# Altium schematic sheet BMB_Microcontroller.SchDoc(BMB_Microcontroller) (continued)

## Library data of the parts
C1 C2 C7 C9 C12-C15 C31 C35 C36 C40 = CAP: Capacitor; Manufacturer Part Number: GRM188R71E104KA01D; Manufacturer: Murata; Voltage Rating (DC): 25V; Tolerance: 10%; Material: Ceramic; Dielectric: X7R; Width: 0.8mm; Weight: 7.1E-05oz; Voltage Rating: 25V; Voltage: 25V; Thickness: 0.035inch; Termination: SMD/SMT; Supplier Part Number 1: 490-1524-1-ND; Supplier 1: Digikey; Stock 1: 6777948; REACH SVHC: Unknown; Radiation Hardening: No; Pricing 1: 1=0.1, 10=0.022, 100=0.0099, 500=0.0071, 1000=0.0056 (USD); Packaging: Tape and Reel; Package Quantity: 1; Number of Pins: 2; Mount: Surface Mount; Min Operating Temperature: -55°C; Max Operating Temperature: 125°C; Library Name: Formula_Library.SchLib; Length: 1.6mm; Lead Free: Lead Free; Height: 0.8mm; Depth: 0.8mm; ComponentLink8URL: https://datasheet.ciiva.com/29202/451555-da-01-en-vs-kondensator-0603-29202150.pdf; ComponentLink8Description: https://datasheet.ciiva.com/29202/451555-da-01-en-vs-kondensator-0603-29202150.pdf; ComponentLink7URL: https://datasheet.ciiva.com/18101/getdatasheetpartid-44549-18101373.pdf; ComponentLink7Description: https://datasheet.ciiva.com/18101/getdatasheetpartid-44549-18101373.pdf; ComponentLink6URL: https://datasheet.ciiva.com/34302/1857495-34302860.pdf; ComponentLink6Description: https://datasheet.ciiva.com/34302/1857495-34302860.pdf; ComponentLink5URL: https://datasheet.ciiva.com/22703/1747454-22703336.pdf; ComponentLink5Description: https://datasheet.ciiva.com/22703/1747454-22703336.pdf; ComponentLink4URL: https://datasheet.ciiva.com/16199/product-16199382.pdf; ComponentLink4Description: https://datasheet.ciiva.com/16199/product-16199382.pdf; ComponentLink3URL: https://datasheet.ciiva.com/129/partnumbering-e-01-129842.pdf; ComponentLink3Description: https://datasheet.ciiva.com/129/partnumbering-e-01-129842.pdf; ComponentLink2URL: https://datasheet.ciiva.com/129/partnumbering-e-01-129841.pdf; ComponentLink2Description: https://datasheet.ciiva.com/129/partnumbering-e-01-129841.pdf; ComponentLink1URL: https://datasheet.ciiva.com/129/product-129840.pdf; ComponentLink1Description: https://datasheet.ciiva.com/129/product-129840.pdf; Component Kind: Standard; Case Code (Metric): 1608; Case Code (Imperial): 0603; Case/Package: 0603
C3-C6 C8 C10 C11 = CAP: Capacitor; Manufacturer Part Number: C0603C102K5RACAUTO; Manufacturer: KEMET; Voltage Rating (DC): 50V; Tolerance: 10%; Dissipation Factor: 2.5%; Dielectric Material: Ceramic; Dielectric: X7R; Width: 0.031inch; Voltage Rating: 50V; Thickness: 0.034inch; Termination: SMD/SMT; Supplier Part Number 1: 399-6849-1-ND; Supplier 1: Digi-Key; Stock 1: 45295; REACH SVHC: No SVHC; Radiation Hardening: No; Pricing 1: 1=0.1, 10=0.076, 100=0.0341, 500=0.0243, 1000=0.0191 (USD); Packaging: Tape and Reel; Package Quantity: 1; Mount: Surface Mount; Min Operating Temperature: -55°C; Max Operating Temperature: 125°C; Library Name: Formula_Library.SchLib; Length: 1.6mm; Height: 0.8mm; Depth: 0.8mm; ComponentLink2URL: https://datasheet.ciiva.com/22693/1633156-22693960.pdf; ComponentLink2Description: https://datasheet.ciiva.com/22693/1633156-22693960.pdf; ComponentLink1URL: https://datasheet.ciiva.com/7823/1633156-7823575.pdf; ComponentLink1Description: https://datasheet.ciiva.com/7823/1633156-7823575.pdf; Component Kind: Standard; Case Code (Metric): 1608; Case Code (Imperial): 0603; Case/Package: 0603
C16 C21 = Cap: Capacitor; Manufacturer Part Number: CGA3E2X7R1H103K080AA; Manufacturer: TDK Corporation; Voltage - Rated: 50V; Tolerance: ±10%; Temperature Coefficient: X7R; Thickness (Max): 0.035" (0.90mm); Supplier Part Number 1: 478-1227-1-ND; Supplier 1: Digi-Key; Stock: 148450; Size / Dimension: 0.063" L x 0.031" W (1.60mm x 0.80mm); Simulation: CAP; Signal Integrity: Cap; RoHS: RoHS Compliant; Ratings: AEC-Q200; Pricing: 1=0.1, 10=0.036, 100=0.0165, 250=0.01352, 500=0.01156, 1000=0.009 (USD); Packaging: Cut Tape (CT); Package / Case: 0603 (1608 Metric); Operating Temperature: -55°C ~ 125°C; Mounting Type: Surface Mount, MLCC; Library Name: Miscellaneous Devices.IntLib; Lead Style: -; Lead Spacing: -; Height - Seated (Max): -; Features: -; ComponentLink1URL: https://product.tdk.com/info/en/catalog/datasheets/mlcc_automotive_general_en.pdf; ComponentLink1Description: https://product.tdk.com/info/en/catalog/datasheets/mlcc_automotive_general_en.pdf; Component Kind: Standard; Category: Capacitors; Applications: Automotive
C17-C20 C22-C26 C39 = Cap: Capacitor; Manufacturer Part Number: CGA3E1X7R1E105M080AC; Manufacturer: TDK Corporation; Voltage - Rated: 25V; Tolerance: ±20%; Temperature Coefficient: X7R; Thickness (Max): 0.035" (0.90mm); Supplier Part Number 1: 490-1536-1-ND; Supplier 1: Digi-Key; Stock: 23693; Size / Dimension: 0.063" L x 0.031" W (1.60mm x 0.80mm); Simulation: CAP; Signal Integrity: Cap; RoHS: RoHS Compliant; Ratings: AEC-Q200; Pricing: 1=0.17, 10=0.12, 100=0.057, 250=0.048, 500=0.0402, 1000=0.033 (USD); Packaging: Cut Tape (CT); Package / Case: 0603 (1608 Metric); Operating Temperature: -55°C ~ 125°C; Mounting Type: Surface Mount, MLCC; Library Name: Miscellaneous Devices.IntLib; Lead Style: -; Lead Spacing: -; Height - Seated (Max): -; Features: -; ComponentLink1URL: https://product.tdk.com/info/en/catalog/datasheets/mlcc_automotive_general_en.pdf; ComponentLink1Description: https://product.tdk.com/info/en/catalog/datasheets/mlcc_automotive_general_en.pdf; Component Kind: Standard; Category: Capacitors; Applications: Automotive
D1 D9 = Green_LED_1: LED GREEN CLEAR 0603 SMD; Manufacturer Part Number: LTST-C190KFKT; Manufacturer: Vishay Lite-On; Wavelength: 611nm; Viewing Angle: 130°; Test Current: 20mA; Power Rating: 75mW; Number of LEDs: 1; Luminous Intensity: 90mcd; Lens Style: Clear,Diffused,Colorless,Rectangular; Lens Colour: Clear,Colorless; Forward Voltage: 2V; Forward Current: 30mA; Dominant Wavelength: 605nm; Width: 0.8mm; Supplier Part Number 1: 160-1434-1-ND; Supplier 1: Digi-Key; Stock 1: 47764; Radiation Hardening: No; Pricing 1: 1=0.25, 10=0.183, 25=0.1348, 100=0.0963, 250=0.0654, 500=0.0578, 1000=0.0452 (USD); Power Dissipation: 75mW; Packaging: Tape and Reel; Package Quantity: 1; Number of Pins: 2; Number of Elements: 1; Mount: Surface Mount; Min Operating Temperature: -55°C; Max Operating Temperature: 85°C; Library Name: Formula_Library.SchLib; Lens Transparency: Clear; Length: 3.2mm; Lead Free: Lead Free; Illumination Colour: Orange; Height: 0.8mm; Depth: 1.6mm; ComponentLink7URL: https://datasheet.ciiva.com/15201/getdatasheetpartid-309341-15201388.pdf; ComponentLink7Description: https://datasheet.ciiva.com/15201/getdatasheetpartid-309341-15201388.pdf; ComponentLink6URL: https://datasheet.ciiva.com/2109/s-110-ltst-c190kfkt-2109978.pdf; ComponentLink6Description: https://datasheet.ciiva.com/2109/s-110-ltst-c190kfkt-2109978.pdf; ComponentLink5URL: https://datasheet.ciiva.com/2109/s-110-ltst-c190kfkt-2109977.pdf; ComponentLink5Description: https://datasheet.ciiva.com/2109/s-110-ltst-c190kfkt-2109977.pdf; ComponentLink4URL: https://datasheet.ciiva.com/11774/0900766b80dc02a7-11774744.pdf; ComponentLink4Description: https://datasheet.ciiva.com/11774/0900766b80dc02a7-11774744.pdf; ComponentLink3URL: https://datasheet.ciiva.com/2109/s-110-ltst-c190kfkt-2109976.pdf; ComponentLink3Description: https://datasheet.ciiva.com/2109/s-110-ltst-c190kfkt-2109976.pdf; ComponentLink2URL: https://datasheet.ciiva.com/16396/lite-on20ltst-c190kfkt-16396246.pdf; ComponentLink2Description: https://datasheet.ciiva.com/16396/lite-on20ltst-c190kfkt-16396246.pdf; ComponentLink1URL: https://datasheet.ciiva.com/12400/100-ltst-c190kfkt-53640-12400769.pdf; ComponentLink1Description: https://datasheet.ciiva.com/12400/100-ltst-c190kfkt-53640-12400769.pdf; Component Kind: Standard; Colour: Orange; Case Code (Metric): 1608; Case Code (Imperial): 0603; Case/Package: 0603
D8 D10 = Blue LED: Manufacturer Part Number: LTST-C193KSKT-5A; Manufacturer: Vishay Lite-On; Viewing Angle: 130°; Test Current: 5mA; Power Dissipation: 75mW; Number of LEDs: 1; Luminous Intensity: 45mcd; Lens Transparency: Clear; Lens Style: Diffused,Colorless,Rectangular; Lens Colour: Clear,Colorless; Forward Voltage: 2.3V; Forward Current: 30mA; Dominant Wavelength: 594.5nm; Width: 0.8mm; Supplier Part Number 1: 160-1831-6-ND; Supplier 1: Digi-Key; Stock 1: 55535; Radiation Hardening: No; Pricing 1: 1=0.41, 10=0.296, 25=0.2268, 100=0.148, 250=0.1085, 500=0.0921, 1000=0.0757, 2500=0.0658 (USD); Packaging: Tape and Reel; Package Quantity: 1; Number of Pins: 2; Number of Elements: 1; Mount: Surface Mount; Min Operating Temperature: -55°C; Max Operating Temperature: 85°C; Library Name: Formula_Library.SchLib; Length: 1.6mm; Lead Free: Lead Free; Illumination Colour: Yellow; Height: 0.35mm; Depth: 0.8mm; ComponentLink6URL: https://datasheet.ciiva.com/4688/lite-on-ltst-c193kskt-5a-187434-4688750.pdf; ComponentLink6Description: https://datasheet.ciiva.com/4688/lite-on-ltst-c193kskt-5a-187434-4688750.pdf; ComponentLink5URL: https://datasheet.ciiva.com/11775/0900766b80dc0553-11775611.pdf; ComponentLink5Description: https://datasheet.ciiva.com/11775/0900766b80dc0553-11775611.pdf; ComponentLink4URL: https://datasheet.ciiva.com/2110/s-110-ltst-c193kskt-5a-2110278.pdf; ComponentLink4Description: https://datasheet.ciiva.com/2110/s-110-ltst-c193kskt-5a-2110278.pdf; ComponentLink3URL: https://datasheet.ciiva.com/2110/s-110-ltst-c193kskt-5a-2110277.pdf; ComponentLink3Description: https://datasheet.ciiva.com/2110/s-110-ltst-c193kskt-5a-2110277.pdf; ComponentLink2URL: https://datasheet.ciiva.com/26890/getdatasheetpartid-683868-26890500.pdf; ComponentLink2Description: https://datasheet.ciiva.com/26890/getdatasheetpartid-683868-26890500.pdf; ComponentLink1URL: https://datasheet.ciiva.com/2110/s-110-ltst-c193kskt-5a-2110276.pdf; ComponentLink1Description: https://datasheet.ciiva.com/2110/s-110-ltst-c193kskt-5a-2110276.pdf; Component Kind: Standard; Colour: Yellow; Case Code (Metric): 1608; Case Code (Imperial): 0603; Case/Package: 0603
D11 = Blue LED: Manufacturer Part Number 1: LTST-C193TBKT-5A; Manufacturer 1: Lite-On Inc.; Wavelength - Peak: 468nm; Wavelength - Dominant: 470nm; Voltage - Forward (Vf) (Typ): 2.8V; Viewing Angle: 130°; Millicandela Rating: 15mcd; Lens Transparency: Clear; Lens Style/Size: Rectangle with Flat Top, 1.15mm x 0.80mm; Lens Color: Colorless; Description 1: LED BLUE CLEAR 0603 SMD; Current - Test: 5mA; Category 1: Optoelectronics; Supplier Part Number 1: 160-1827-1-ND; Supplier 1: Digi-Key; Stock 1: 521008; Size / Dimension: 1.60mm L x 0.80mm W; RoHS: RoHS Compliant; Pricing 1: 1=0.47, 10=0.336, 25=0.2572, 100=0.1678, 250=0.12304, 500=0.10442, 1000=0.08577 (USD); Packaging: Cut Tape (CT); Package / Case: 0603 (1608 Metric); Mounting Type: Surface Mount; Library Name: Formula_Library.SchLib; Height (Max): 0.35mm; Features: -; ComponentLink1URL: http://optoelectronics.liteon.com/upload/download/DS22-2004-060/P_100_LTST-C193TBKT-5A.pdf; ComponentLink1Description: http://optoelectronics.liteon.com/upload/download/DS22-2004-060/P_100_LTST-C193TBKT-5A.pdf; Component Kind: Standard; Color: Blue
J14 J15 = TM4C123G_Headers: Header to connect to screen; Library Name: Formula_Library.SchLib; Component Kind: Standard
L1 L2 = Common Mode Choke: Manufacturer: Bourns; Tolerance: 50%; Test Frequency: 100KHz; Number of Lines: 2; Max DC Current: 200mA; Material: Steel; Insulation Resistance: 10MR; Inductance: 100uh; Impedance: 5KR; DC Resistance (DCR): 8.9R; Core Material: Ferrite; Width: 0.126inch; Termination: SMD/SMT; Supplier Part Number 1: SRF4532-101YDKR-ND; Supplier 1: Digi-Key; Stock 1: 15996; Simulation: INDUCTOR; Signal Integrity: Inductor; Self Resonant Frequency: 100KHz; REACH SVHC: No SVHC; Pricing 1: 1=0.7, 10=0.616, 100=0.4399 (USD); Packaging: Tape and Reel; Package Quantity: 1; Min Operating Temperature: -40°C; Max Operating Temperature: 125°C; Library Name: Formula_Library.SchLib; Length: 4.5mm; Lead Free: Lead Free; Height: 0.118inch; Description: Common Mode Choke; Depth: 3.4mm; DC Current: 200mA; Current Rating: 200mA; Current: 200mA; ComponentLink6URL: https://datasheet.ciiva.com/48686/001056376-da-01-en-indukt-kom-mod-srf4532-101y-100uh-0-2a-48686764.pdf; ComponentLink6Description: https://datasheet.ciiva.com/48686/001056376-da-01-en-indukt-kom-mod-srf4532-101y-100uh-0-2a-48686764.pdf; ComponentLink5URL: https://datasheet.ciiva.com/22874/70301203-22874428.pdf; ComponentLink5Description: https://datasheet.ciiva.com/22874/70301203-22874428.pdf; ComponentLink4URL: https://datasheet.ciiva.com/7847/srf4532-7847899.pdf; ComponentLink4Description: https://datasheet.ciiva.com/7847/srf4532-7847899.pdf; ComponentLink3URL: https://datasheet.ciiva.com/6216/srf4532-6216137.pdf; ComponentLink3Description: https://datasheet.ciiva.com/6216/srf4532-6216137.pdf; ComponentLink2URL: https://datasheet.ciiva.com/22628/1911192-22628118.pdf; ComponentLink2Description: https://datasheet.ciiva.com/22628/1911192-22628118.pdf; ComponentLink1URL: https://datasheet.ciiva.com/6216/srf4532-6216134.pdf; ComponentLink1Description: https://datasheet.ciiva.com/6216/srf4532-6216134.pdf; Component Kind: Standard; Case/Package: 4532
Q1 = MOSFET-N: N-Channel MOSFET; Manufacturer: STMicroelectronics; Voltage Rating (DC): 600V; Turn-On Delay Time: 5.5ns; Turn-Off Delay Time: 13ns; Threshold Voltage: 3.75V; Rise Time: 5ns; Rds On Max: 15R; Power Dissipation: 3.3W; Number of Elements: 1; Input Capacitance: 94pF; Gate to Source Voltage (Vgs): 30V; Fall Time: 18ns; Element Configuration: Single; Current Rating: 250mA; Continuous Drain Current (ID): 300mA; Width: 3.5mm; Weight: 0.01lb; Supplier Part Number 1: 497-3523-6-ND; Supplier 1: Digi-Key; Stock 1: 39853; Simulation: NMOS; REACH SVHC: No SVHC; Radiation Hardening: No; Pricing 1: 1=0.67, 10=0.587, 100=0.45, 500=0.3558, 1000=0.2846 (USD); Packaging: Tape and Reel; Package Quantity: 4000; Number of Pins: 4; Mount: Surface Mount; Min Operating Temperature: -55°C; Max Power Dissipation: 3.3W; Max Operating Temperature: 150°C; Library Name: Formula_Library.SchLib; Length: 6.5mm; Lead Free: Lead Free; Height: 1.8mm; Drain to Source Voltage (Vdss): 600V; Drain to Source Resistance: 15R; Drain to Source Breakdown Voltage: 600V; ComponentLink5URL: https://datasheet.ciiva.com/26911/getdatasheetpartid-74454-26911934.pdf; ComponentLink5Description: https://datasheet.ciiva.com/26911/getdatasheetpartid-74454-26911934.pdf; ComponentLink4URL: https://datasheet.ciiva.com/22712/1786014-22712606.pdf; ComponentLink4Description: https://datasheet.ciiva.com/22712/1786014-22712606.pdf; ComponentLink3URL: https://datasheet.ciiva.com/4441/cd00003347-42870-4441147.pdf; ComponentLink3Description: https://datasheet.ciiva.com/4441/cd00003347-42870-4441147.pdf; ComponentLink2URL: https://datasheet.ciiva.com/16449/cd00003347-16449159.pdf; ComponentLink2Description: https://datasheet.ciiva.com/16449/cd00003347-16449159.pdf; ComponentLink1URL: https://datasheet.ciiva.com/8025/cd00003347-8025266.pdf; ComponentLink1Description: https://datasheet.ciiva.com/8025/cd00003347-8025266.pdf; Component Kind: Standard; Case/Package: SOT-223
R11-R13 R16 = Res1: Resistor; Manufacturer Part Number: RC0603JR-07100RL; Manufacturer: Yageo; Tolerance: ±5%; Temperature Coefficient: ±100ppm/°C; Resistance (Ohms): 100; Power (Watts): 0.1W, 1/10W; Supplier Part Number 1: 311-100GRCT-ND; Supplier Device Package: 0603; Supplier 1: Digi-Key; Stock 1: 1986696; Size / Dimension: 0.063" L x 0.031" W (1.60mm x 0.80mm); Simulation: RESISTOR; Signal Integrity: Res; RoHS: RoHS Compliant; Pricing 1: 1=0.1, 10=0.01, 25=0.0072, 50=0.0054, 100=0.004, 250=0.00308, 500=0.00244, 1000=0.0018 (USD); Part Status: Active; Packaging: Cut Tape (CT); Package / Case: 0603 (1608 Metric); Operating Temperature: -55°C ~ 155°C; Library Name: Miscellaneous Devices.IntLib; Height - Seated (Max): 0.022" (0.55mm); Features: Moisture Resistant; Failure Rate: -; Composition: Thick Film; ComponentLink1URL: http://www.yageo.com/documents/recent/PYu-RC_Group_51_RoHS_L_6.pdf; ComponentLink1Description: http://www.yageo.com/documents/recent/PYu-RC_Group_51_RoHS_L_6.pdf; Component Kind: Standard; Category: Resistors
R14 R15 = Res1: Resistor; Manufacturer Part Number: ERJ-8GEYJ620V; Manufacturer: Panasonic Electronic Components; Tolerance: ±5%; Temperature Coefficient: ±200ppm/°C; Resistance (Ohms): 62; Power (Watts): 0.25W, 1/4W; Supplier Part Number 1: P62ECT-ND; Supplier Device Package: 1206; Supplier 1: Digi-Key; Stock: 828247; Size / Dimension: 0.126" L x 0.063" W (3.20mm x 1.60mm); Simulation: RESISTOR; Signal Integrity: Res; RoHS: RoHS Compliant; Pricing: 1=0.1, 50=0.0212, 100=0.0156, 250=0.01188, 500=0.0095, 1000=0.007 (USD); Packaging: Cut Tape (CT); Package / Case: 1206 (3216 Metric); Operating Temperature: -55°C ~ 155°C; Number of Terminations: 2; Library Name: Miscellaneous Devices.IntLib; Height: 0.028" (0.70mm); Features: Automotive AEC-Q200; Composition: Thick Film; ComponentLink1URL: http://industrial.panasonic.com/www-cgi/jvcr13pz.cgi?E+PZ+3+AOA0001+ERJ8GEYJ620V+7+WW; ComponentLink1Description: http://industrial.panasonic.com/www-cgi/jvcr13pz.cgi?E+PZ+3+AOA0001+ERJ8GEYJ620V+7+WW; Component Kind: Standard; Category: Resistors
R17 R18 = Res1: Resistor; Manufacturer Part Number: RMCF0603FT4K70; Manufacturer: Stackpole Electronics; Tolerance: 1%; Temperature Coefficient: 100ppm/°C; Power Rating: 100mW; Width: 0.031inch; Supplier Part Number 1: RMCF0603FT4K70DKR-ND; Supplier 1: Digikey; Stock 1: 722170; Simulation: RESISTOR; Signal Integrity: Res; Radiation Hardening: No; Pricing 1: 1=0.1, 10=0.019, 100=0.0077, 1000=0.0035, 2500=0.003 (USD); Packaging: Tape and Reel; Number of Terminations: 2; Min Operating Temperature: -55°C; Military Standard: Not; Max Power Dissipation: 100mW; Max Operating Temperature: 155°C; Library Name: Miscellaneous Devices.IntLib; Length: 1.55mm; Lead Free: Lead Free; Height: 0.022inch; Depth: 0.8mm; Contact Plating: Tin; Composition: Thick Film; ComponentLink1URL: https://datasheet.ciiva.com/26835/getdatasheetpartid-486078-26835642.pdf; ComponentLink1Description: https://datasheet.ciiva.com/26835/getdatasheetpartid-486078-26835642.pdf; Component Kind: Standard; Case Code (Metric): 1608; Case Code (Imperial): 0603; Case/Package: 0603
R19 R35 = Res1: Resistor; Manufacturer Part Number: RC0603JR-071KL; Manufacturer: Yageo; Tolerance: ±5%; Temperature Coefficient: ±100ppm/°C; Power (Watts): 0.1W, 1/10W; Supplier Part Number 1: 311-1.0KGRCT-ND; Supplier Device Package: 0603; Supplier 1: Digi-Key; Stock 1: 1140430; Size / Dimension: 0.063" L x 0.031" W (1.60mm x 0.80mm); Simulation: RESISTOR; Signal Integrity: Res; RoHS: RoHS Compliant; Pricing 1: 1=0.1, 10=0.011, 25=0.008, 100=0.0044, 250=0.00336, 500=0.0027, 1000=0.00198, 2500=0.00172 (USD); Packaging: Cut Tape (CT); Package / Case: 0603 (1608 Metric); Operating Temperature: -55°C ~ 155°C; Number of Terminations: 2; Library Name: Miscellaneous Devices.IntLib; Height: 0.022" (0.55mm); Features: Moisture Resistant; Composition: Thick Film; ComponentLink1URL: http://www.yageo.com/documents/recent/PYu-RC_Group_51_RoHS_L_04.pdf; ComponentLink1Description: http://www.yageo.com/documents/recent/PYu-RC_Group_51_RoHS_L_04.pdf; Component Kind: Standard; Category: Resistors
R20 = Res1: Resistor; Manufacturer Part Number: ERJ-3GEYJ152V; Manufacturer: Panasonic ECG; Voltage Rating (DC): 50V; Voltage Rating: 75V; Tolerance: 5%; Temperature Coefficient: 200ppm/°C; Power Rating: 100mW; Width: 0.031inch; Termination: SMD/SMT; Supplier Part Number 1: P1.5KGCT-ND; Supplier 1: Digi-Key; Simulation: RESISTOR; Signal Integrity: Res; REACH SVHC: No SVHC; Radiation Hardening: No; Pricing 1: 1=0.1, 10=0.038, 100=0.0153, 1000=0.0069, 2500=0.006 (USD); Packaging: Tape and Reel; Package Quantity: 1; Number of Terminations: 2; Mount: Surface Mount; Min Operating Temperature: -55°C; Military Standard: Not; Max Power Dissipation: 100mW; Max Operating Temperature: 155°C; Library Name: Miscellaneous Devices.IntLib; Length: 1.6mm; Lead Free: Lead Free; Height: 0.45mm; Depth: 0.8mm; Contact Plating: Tin; Composition: Thick Film; ComponentLink11URL: https://datasheet.ciiva.com/43460/1500035-43460718.pdf; ComponentLink11Description: https://datasheet.ciiva.com/43460/1500035-43460718.pdf; ComponentLink10URL: https://datasheet.ciiva.com/46389/1500035-46389821.pdf; ComponentLink10Description: https://datasheet.ciiva.com/46389/1500035-46389821.pdf; ComponentLink9URL: https://datasheet.ciiva.com/22758/1500035-22758221.pdf; ComponentLink9Description: https://datasheet.ciiva.com/22758/1500035-22758221.pdf; ComponentLink8URL: https://datasheet.ciiva.com/16775/70255313-16775772.pdf; ComponentLink8Description: https://datasheet.ciiva.com/16775/70255313-16775772.pdf; ComponentLink7URL: https://datasheet.ciiva.com/46380/1500035-46380939.pdf; ComponentLink7Description: https://datasheet.ciiva.com/46380/1500035-46380939.pdf; ComponentLink6URL: https://datasheet.ciiva.com/34303/1988541-34303611.pdf; ComponentLink6Description: https://datasheet.ciiva.com/34303/1988541-34303611.pdf; ComponentLink5URL: https://datasheet.ciiva.com/28514/1678796-28514219.pdf; ComponentLink5Description: https://datasheet.ciiva.com/28514/1678796-28514219.pdf; ComponentLink4URL: https://datasheet.ciiva.com/11821/0900766b80f806dd-11821434.pdf; ComponentLink4Description: https://datasheet.ciiva.com/11821/0900766b80f806dd-11821434.pdf; ComponentLink3URL: https://datasheet.ciiva.com/16776/70255313-16776473.pdf; ComponentLink3Description: https://datasheet.ciiva.com/16776/70255313-16776473.pdf; ComponentLink2URL: https://datasheet.ciiva.com/9117/aoa0000ce1-9117081.pdf; ComponentLink2Description: https://datasheet.ciiva.com/9117/aoa0000ce1-9117081.pdf; ComponentLink1URL: https://datasheet.ciiva.com/15159/getdatasheetpartid-29191-15159269.pdf; ComponentLink1Description: https://datasheet.ciiva.com/15159/getdatasheetpartid-29191-15159269.pdf; Component Kind: Standard; Case Code (Metric): 1608; Case Code (Imperial): 0603; Case/Package: 0603
R21 R22 = Res1: Resistor; Manufacturer Part Number: RC0603FR-0760R4L; Manufacturer: Yageo; Voltage Rating (DC): 50V; Tolerance: 1%; Temperature Coefficient: 100ppm/°C; Power Rating: 100mW; Width: 0.8mm; Voltage Rating: 50V; Termination: SMD/SMT; Supplier Part Number 1: 311-60.4HRDKR-ND; Supplier 1: Digi-Key; Stock 1: 43153; Simulation: RESISTOR; Signal Integrity: Res; Pricing 1: 1=0.1, 10=0.015, 100=0.006, 1000=0.0027, 2500=0.0023 (USD); Packaging: Tape and Reel; Min Operating Temperature: -55°C; Military Standard: Not; Max Operating Temperature: 155°C; Library Name: Miscellaneous Devices.IntLib; Length: 1.6mm; Lead Free: Lead Free; Height: 0.45mm; Depth: 0.8mm; Composition: Thick Film; ComponentLink1URL: https://datasheet.ciiva.com/12558/rc0603-272842-12558933.pdf; ComponentLink1Description: https://datasheet.ciiva.com/12558/rc0603-272842-12558933.pdf; Component Kind: Standard; Case Code (Metric): 1608; Case Code (Imperial): 0603; Case/Package: 0603
R23-R26 R34 R36 R37 R49 = Res1: 10K resistor; Manufacturer Part Number: ERJ-3GEYJ103V; Manufacturer: Panasonic / Panasonic ECG; Tolerance (%): ±5 %; Temp Coefficient: ±200 ppm/°C; Rated Power: 0.1 W; Supplier Part Number 1: P10KGCT-ND; Supplier 1: Digikey; Stock 1: 6640574; Size Code: 0603; Simulation: RESISTOR; Signal Integrity: Res; Pricing 1: 1=0.1, 10=0.016, 25=0.0116, 50=0.0088, 100=0.0065, 250=0.005, 500=0.004, 1000=0.0029 (USD); Library Name: Miscellaneous Devices.IntLib; ComponentLink11URL: https://datasheet.ciiva.com/48531/aoa0000ce1-48531887.pdf; ComponentLink11Description: https://datasheet.ciiva.com/48531/aoa0000ce1-48531887.pdf; ComponentLink10URL: https://datasheet.ciiva.com/16740/aoa0000ce1-16740655.pdf; ComponentLink10Description: https://datasheet.ciiva.com/16740/aoa0000ce1-16740655.pdf; ComponentLink9URL: https://datasheet.ciiva.com/11809/0900766b80f806dd-11809566.pdf; ComponentLink9Description: https://datasheet.ciiva.com/11809/0900766b80f806dd-11809566.pdf; ComponentLink8URL: https://datasheet.ciiva.com/9117/aoa0000ce1-9117038.pdf; ComponentLink8Description: https://datasheet.ciiva.com/9117/aoa0000ce1-9117038.pdf; ComponentLink7URL: https://datasheet.ciiva.com/34303/2007265-34303757.pdf; ComponentLink7Description: https://datasheet.ciiva.com/34303/2007265-34303757.pdf; ComponentLink6URL: https://datasheet.ciiva.com/17212/aoa0000ce1-17212106.pdf; ComponentLink6Description: https://datasheet.ciiva.com/17212/aoa0000ce1-17212106.pdf; ComponentLink5URL: https://datasheet.ciiva.com/46385/1678796-46385240.pdf; ComponentLink5Description: https://datasheet.ciiva.com/46385/1678796-46385240.pdf; ComponentLink4URL: https://datasheet.ciiva.com/24133/aoa0000ce1-37422-24133064.pdf; ComponentLink4Description: https://datasheet.ciiva.com/24133/aoa0000ce1-37422-24133064.pdf; ComponentLink3URL: https://datasheet.ciiva.com/46371/1678796-46371909.pdf; ComponentLink3Description: https://datasheet.ciiva.com/46371/1678796-46371909.pdf; ComponentLink2URL: https://datasheet.ciiva.com/30147/4aoa0000ce1-30147029.pdf; ComponentLink2Description: https://datasheet.ciiva.com/30147/4aoa0000ce1-30147029.pdf; ComponentLink1URL: https://datasheet.ciiva.com/28514/1678796-28514213.pdf; ComponentLink1Description: https://datasheet.ciiva.com/28514/1678796-28514213.pdf; Component Kind: Standard
R27-R29 = Res1: 10K resistor; Manufacturer Part Number: ERJ-3EKF2491V; Manufacturer: Panasonic ECG; Voltage Rating (DC): 50V; Voltage Rating: 75V; Tolerance: 1%; Temperature Coefficient: 100ppm/°C; Power Rating: 100mW; Width: 0.031inch; Termination: SMD/SMT; Supplier Part Number 1: P2.49KHCT-ND; Supplier 1: Digi-Key; Stock 1: 446271; Simulation: RESISTOR; Signal Integrity: Res; REACH SVHC: No SVHC; Radiation Hardening: No; Pricing 1: 1=0.1, 10=0.021, 100=0.0087, 1000=0.0039, 2500=0.0034 (USD); Packaging: Tape and Reel; Package Quantity: 1; Number of Terminations: 2; Mount: Surface Mount; Min Operating Temperature: -55°C; Military Standard: Not; Max Power Dissipation: 100mW; Max Operating Temperature: 155°C; Library Name: Miscellaneous Devices.IntLib; Length: 1.6mm; Lead Free: Lead Free; Height: 0.45mm; Depth: 0.8mm; Contact Plating: Tin; Composition: Thick Film; ComponentLink7URL: https://datasheet.ciiva.com/22749/1678782-22749613.pdf; ComponentLink7Description: https://datasheet.ciiva.com/22749/1678782-22749613.pdf; ComponentLink6URL: https://datasheet.ciiva.com/15159/getdatasheetpartid-28728-15159196.pdf; ComponentLink6Description: https://datasheet.ciiva.com/15159/getdatasheetpartid-28728-15159196.pdf; ComponentLink5URL: https://datasheet.ciiva.com/43460/426256-43460491.pdf; ComponentLink5Description: https://datasheet.ciiva.com/43460/426256-43460491.pdf; ComponentLink4URL: https://datasheet.ciiva.com/30200/aoa0000ce2-30200873.pdf; ComponentLink4Description: https://datasheet.ciiva.com/30200/aoa0000ce2-30200873.pdf; ComponentLink3URL: https://datasheet.ciiva.com/28694/1678782-28694214.pdf; ComponentLink3Description: https://datasheet.ciiva.com/28694/1678782-28694214.pdf; ComponentLink2URL: https://datasheet.ciiva.com/5969/426256-5969254.pdf; ComponentLink2Description: https://datasheet.ciiva.com/5969/426256-5969254.pdf; ComponentLink1URL: https://datasheet.ciiva.com/34303/1987855-34303585.pdf; ComponentLink1Description: https://datasheet.ciiva.com/34303/1987855-34303585.pdf; Component Kind: Standard; Case Code (Metric): 1608; Case Code (Imperial): 0603; Case/Package: 0603
R30 R39 R41 = Res1: 10K resistor; Manufacturer Part Number: ERJ-3GEYJ332V; Manufacturer: Panasonic ECG; Voltage Rating (DC): 50V; Voltage Rating: 75V; Tolerance: 5%; Temperature Coefficient: 200ppm/°C; Power Rating: 100mW; Width: 0.031inch; Weight: 0.002lb; Termination: SMD/SMT; Supplier Part Number 1: P3.3KGDKR-ND; Supplier 1: Digi-Key; Stock 1: 759747; Simulation: RESISTOR; Signal Integrity: Res; REACH SVHC: No SVHC; Radiation Hardening: No; Pricing 1: 1=0.1, 10=0.018, 100=0.0073, 1000=0.0033, 2500=0.0029 (USD); Packaging: Tape and Reel; Package Quantity: 1; Number of Terminations: 2; Mount: Surface Mount; Min Operating Temperature: -55°C; Military Standard: Not; Max Power Dissipation: 100mW; Max Operating Temperature: 155°C; Library Name: Miscellaneous Devices.IntLib; Length: 1.6mm; Lead Free: Lead Free; Height: 0.45mm; Depth: 0.8mm; Contact Plating: Tin; Composition: Thick Film; ComponentLink11URL: https://datasheet.ciiva.com/16778/70255313-16778477.pdf; ComponentLink11Description: https://datasheet.ciiva.com/16778/70255313-16778477.pdf; ComponentLink10URL: https://datasheet.ciiva.com/6138/1500035-6138173.pdf; ComponentLink10Description: https://datasheet.ciiva.com/6138/1500035-6138173.pdf; ComponentLink9URL: https://datasheet.ciiva.com/46377/1500035-46377560.pdf; ComponentLink9Description: https://datasheet.ciiva.com/46377/1500035-46377560.pdf; ComponentLink8URL: https://datasheet.ciiva.com/9117/aoa0000ce1-9117175.pdf; ComponentLink8Description: https://datasheet.ciiva.com/9117/aoa0000ce1-9117175.pdf; ComponentLink7URL: https://datasheet.ciiva.com/30259/4aoa0000ce1-30259014.pdf; ComponentLink7Description: https://datasheet.ciiva.com/30259/4aoa0000ce1-30259014.pdf; ComponentLink6URL: https://datasheet.ciiva.com/28683/1678796-28683414.pdf; ComponentLink6Description: https://datasheet.ciiva.com/28683/1678796-28683414.pdf; ComponentLink5URL: https://datasheet.ciiva.com/43460/1500035-43460726.pdf; ComponentLink5Description: https://datasheet.ciiva.com/43460/1500035-43460726.pdf; ComponentLink4URL: https://datasheet.ciiva.com/27097/1988735-27097077.pdf; ComponentLink4Description: https://datasheet.ciiva.com/27097/1988735-27097077.pdf; ComponentLink3URL: https://datasheet.ciiva.com/16784/70255313-16784389.pdf; ComponentLink3Description: https://datasheet.ciiva.com/16784/70255313-16784389.pdf; ComponentLink2URL: https://datasheet.ciiva.com/46389/1500035-46389461.pdf; ComponentLink2Description: https://datasheet.ciiva.com/46389/1500035-46389461.pdf; ComponentLink1URL: https://datasheet.ciiva.com/11821/0900766b80f806dd-11821474.pdf; ComponentLink1Description: https://datasheet.ciiva.com/11821/0900766b80f806dd-11821474.pdf; Component Kind: Standard; Case Code (Metric): 1608; Case Code (Imperial): 0603; Case/Package: 0603
R31 R65 = Res1: 10K resistor; Manufacturer Part Number: ERJ-3EKF4700V; Manufacturer: Panasonic ECG; Voltage Rating (DC): 75V; Tolerance: 1%; Temperature Coefficient: 100ppm/°C; Resistance: 470; Power Rating: 100mW; Width: 0.031inch; Voltage Rating: 75V; Termination: SMD/SMT; Supplier Part Number 1: P470HDKR-ND; Supplier 1: Digi-Key; Stock 1: 80835; Simulation: RESISTOR; Signal Integrity: Res; REACH SVHC: No SVHC; Radiation Hardening: No; Pricing 1: 1=0.1, 10=0.021, 100=0.0087, 1000=0.0039, 2500=0.0034 (USD); Packaging: Tape and Reel; Package Quantity: 1; Number of Terminations: 2; Number of Pins: 2; Mount: Surface Mount; Min Operating Temperature: -55°C; Military Standard: Not; Max Power Dissipation: 100mW; Max Operating Temperature: 155°C; Library Name: Miscellaneous Devices.IntLib; Length: 1.6mm; Lead Free: Lead Free; Height: 0.022inch; Depth: 0.8mm; Contact Plating: Tin; Composition: Thick Film; ComponentLink12URL: https://datasheet.ciiva.com/24069/aoa0000ce2-24069535.pdf; ComponentLink12Description: https://datasheet.ciiva.com/24069/aoa0000ce2-24069535.pdf; ComponentLink11URL: https://datasheet.ciiva.com/7830/aoa0000ce2-7830734.pdf; ComponentLink11Description: https://datasheet.ciiva.com/7830/aoa0000ce2-7830734.pdf; ComponentLink10URL: https://datasheet.ciiva.com/16773/70260748-16773597.pdf; ComponentLink10Description: https://datasheet.ciiva.com/16773/70260748-16773597.pdf; ComponentLink9URL: https://datasheet.ciiva.com/17205/aoa0000ce2-17205548.pdf; ComponentLink9Description: https://datasheet.ciiva.com/17205/aoa0000ce2-17205548.pdf; ComponentLink8URL: https://datasheet.ciiva.com/16784/70260748-16784891.pdf; ComponentLink8Description: https://datasheet.ciiva.com/16784/70260748-16784891.pdf; ComponentLink7URL: https://datasheet.ciiva.com/46372/1678782-46372970.pdf; ComponentLink7Description: https://datasheet.ciiva.com/46372/1678782-46372970.pdf; ComponentLink6URL: https://datasheet.ciiva.com/46372/1678782-46372969.pdf; ComponentLink6Description: https://datasheet.ciiva.com/46372/1678782-46372969.pdf; ComponentLink5URL: https://datasheet.ciiva.com/7830/426256-7830984.pdf; ComponentLink5Description: https://datasheet.ciiva.com/7830/426256-7830984.pdf; ComponentLink4URL: https://datasheet.ciiva.com/22687/1678782-22687447.pdf; ComponentLink4Description: https://datasheet.ciiva.com/22687/1678782-22687447.pdf; ComponentLink3URL: https://datasheet.ciiva.com/15159/getdatasheetpartid-45800-15159559.pdf; ComponentLink3Description: https://datasheet.ciiva.com/15159/getdatasheetpartid-45800-15159559.pdf; ComponentLink2URL: https://datasheet.ciiva.com/6138/aoa0000ce2-6138151.pdf; ComponentLink2Description: https://datasheet.ciiva.com/6138/aoa0000ce2-6138151.pdf; ComponentLink1URL: https://datasheet.ciiva.com/16440/aoa0000ce2-16440182.pdf; ComponentLink1Description: https://datasheet.ciiva.com/16440/aoa0000ce2-16440182.pdf; Component Kind: Standard; Case Code (Metric): 1608; Case Code (Imperial): 0603; Case/Package: 0603
R32 = Res1: 1.5K resistor; Manufacturer Part Number: RC0603FR-07100RL; Manufacturer: Yageo; Voltage Rating (DC): 50V; Tolerance: 1%; Temperature Coefficient: 100ppm/°C; Resistance: 100; Power Rating: 100mW; Width: 0.8mm; Voltage Rating: 50V; Termination: SMD/SMT; Supplier Part Number 1: 311-100HRCT-ND; Supplier 1: Digikey; Stock 1: 6020435; Simulation: RESISTOR; Signal Integrity: Res; REACH SVHC: Unknown; Pricing 1: 1=0.1, 10=0.015, 100=0.006, 1000=0.0027, 2500=0.0023 (USD); Packaging: Tape and Reel; Number of Pins: 2; Min Operating Temperature: -55°C; Military Standard: Not; Max Operating Temperature: 155°C; Library Name: Miscellaneous Devices.IntLib; Length: 1.6mm; Lead Free: Lead Free; Height: 0.45mm; Depth: 0.8mm; Composition: Thick Film; ComponentLink7URL: https://datasheet.ciiva.com/22747/443971-22747518.pdf; ComponentLink7Description: https://datasheet.ciiva.com/22747/443971-22747518.pdf; ComponentLink6URL: https://datasheet.ciiva.com/7746/1738695-7746238.pdf; ComponentLink6Description: https://datasheet.ciiva.com/7746/1738695-7746238.pdf; ComponentLink5URL: https://datasheet.ciiva.com/7746/1738695-7746237.pdf; ComponentLink5Description: https://datasheet.ciiva.com/7746/1738695-7746237.pdf; ComponentLink4URL: https://datasheet.ciiva.com/7746/1686128-7746236.pdf; ComponentLink4Description: https://datasheet.ciiva.com/7746/1686128-7746236.pdf; ComponentLink3URL: https://datasheet.ciiva.com/46440/1868787-46440890.pdf; ComponentLink3Description: https://datasheet.ciiva.com/46440/1868787-46440890.pdf; ComponentLink2URL: https://datasheet.ciiva.com/11808/0900766b80f96399-11808280.pdf; ComponentLink2Description: https://datasheet.ciiva.com/11808/0900766b80f96399-11808280.pdf; ComponentLink1URL: https://datasheet.ciiva.com/7660/38590-7660788.pdf; ComponentLink1Description: https://datasheet.ciiva.com/7660/38590-7660788.pdf; Component Kind: Standard; Case Code (Metric): 1608; Case Code (Imperial): 0603; Case/Package: 0603
R33 R38 R40 R44 R47 = Res1: 10K resistor; Manufacturer Part Number: ERJ-3GEYJ152V; Manufacturer: Panasonic ECG; Voltage Rating (DC): 50V; Voltage Rating: 75V; Tolerance: 5%; Temperature Coefficient: 200ppm/°C; Power Rating: 100mW; Width: 0.031inch; Termination: SMD/SMT; Supplier Part Number 1: P1.5KGCT-ND; Supplier 1: Digi-Key; Simulation: RESISTOR; Signal Integrity: Res; REACH SVHC: No SVHC; Radiation Hardening: No; Pricing 1: 1=0.1, 10=0.038, 100=0.0153, 1000=0.0069, 2500=0.006 (USD); Packaging: Tape and Reel; Package Quantity: 1; Number of Terminations: 2; Mount: Surface Mount; Min Operating Temperature: -55°C; Military Standard: Not; Max Power Dissipation: 100mW; Max Operating Temperature: 155°C; Library Name: Miscellaneous Devices.IntLib; Length: 1.6mm; Lead Free: Lead Free; Height: 0.45mm; Depth: 0.8mm; Contact Plating: Tin; Composition: Thick Film; ComponentLink11URL: https://datasheet.ciiva.com/43460/1500035-43460718.pdf; ComponentLink11Description: https://datasheet.ciiva.com/43460/1500035-43460718.pdf; ComponentLink10URL: https://datasheet.ciiva.com/46389/1500035-46389821.pdf; ComponentLink10Description: https://datasheet.ciiva.com/46389/1500035-46389821.pdf; ComponentLink9URL: https://datasheet.ciiva.com/22758/1500035-22758221.pdf; ComponentLink9Description: https://datasheet.ciiva.com/22758/1500035-22758221.pdf; ComponentLink8URL: https://datasheet.ciiva.com/16775/70255313-16775772.pdf; ComponentLink8Description: https://datasheet.ciiva.com/16775/70255313-16775772.pdf; ComponentLink7URL: https://datasheet.ciiva.com/46380/1500035-46380939.pdf; ComponentLink7Description: https://datasheet.ciiva.com/46380/1500035-46380939.pdf; ComponentLink6URL: https://datasheet.ciiva.com/34303/1988541-34303611.pdf; ComponentLink6Description: https://datasheet.ciiva.com/34303/1988541-34303611.pdf; ComponentLink5URL: https://datasheet.ciiva.com/28514/1678796-28514219.pdf; ComponentLink5Description: https://datasheet.ciiva.com/28514/1678796-28514219.pdf; ComponentLink4URL: https://datasheet.ciiva.com/11821/0900766b80f806dd-11821434.pdf; ComponentLink4Description: https://datasheet.ciiva.com/11821/0900766b80f806dd-11821434.pdf; ComponentLink3URL: https://datasheet.ciiva.com/16776/70255313-16776473.pdf; ComponentLink3Description: https://datasheet.ciiva.com/16776/70255313-16776473.pdf; ComponentLink2URL: https://datasheet.ciiva.com/9117/aoa0000ce1-9117081.pdf; ComponentLink2Description: https://datasheet.ciiva.com/9117/aoa0000ce1-9117081.pdf; ComponentLink1URL: https://datasheet.ciiva.com/15159/getdatasheetpartid-29191-15159269.pdf; ComponentLink1Description: https://datasheet.ciiva.com/15159/getdatasheetpartid-29191-15159269.pdf; Component Kind: Standard; Case Code (Metric): 1608; Case Code (Imperial): 0603; Case/Package: 0603
R63 R64 = Res1: Resistor; Manufacturer Part Number: ERJ-3EKF2940V; Manufacturer: Panasonic ECG; Voltage Rating (DC): 50V; Voltage Rating: 75V; Tolerance: 1%; Temperature Coefficient: 100ppm/°C; Resistance: 294; Power Rating: 100mW; Width: 0.8mm; Termination: SMD/SMT; Supplier Part Number 1: P294HDKR-ND; Supplier 1: Digi-Key; Stock 1: 83146; Simulation: RESISTOR; Signal Integrity: Res; REACH SVHC: No SVHC; Pricing 1: 1=0.1, 10=0.021, 100=0.0087, 1000=0.0039, 2500=0.0034 (USD); Packaging: Tape and Reel; Package Quantity: 1; Number of Terminations: 2; Mount: Surface Mount; Min Operating Temperature: -55°C; Military Standard: Not; Max Power Dissipation: 100mW; Max Operating Temperature: 155°C; Library Name: Miscellaneous Devices.IntLib; Length: 1.6mm; Lead Free: Lead Free; Height: 0.45mm; Depth: 0.8mm; Contact Plating: Tin; Composition: Thick Film; ComponentLink7URL: https://datasheet.ciiva.com/9183/aoa0000ce2-9183310.pdf; ComponentLink7Description: https://datasheet.ciiva.com/9183/aoa0000ce2-9183310.pdf; ComponentLink6URL: https://datasheet.ciiva.com/28540/1694929-28540748.pdf; ComponentLink6Description: https://datasheet.ciiva.com/28540/1694929-28540748.pdf; ComponentLink5URL: https://datasheet.ciiva.com/22729/1678782-22729145.pdf; ComponentLink5Description: https://datasheet.ciiva.com/22729/1678782-22729145.pdf; ComponentLink4URL: https://datasheet.ciiva.com/16763/70260748-16763193.pdf; ComponentLink4Description: https://datasheet.ciiva.com/16763/70260748-16763193.pdf; ComponentLink3URL: https://datasheet.ciiva.com/22674/1678782-22674296.pdf; ComponentLink3Description: https://datasheet.ciiva.com/22674/1678782-22674296.pdf; ComponentLink2URL: https://datasheet.ciiva.com/46437/1678782-46437830.pdf; ComponentLink2Description: https://datasheet.ciiva.com/46437/1678782-46437830.pdf; ComponentLink1URL: https://datasheet.ciiva.com/7942/1678782-7942373.pdf; ComponentLink1Description: https://datasheet.ciiva.com/7942/1678782-7942373.pdf; Component Kind: Standard; Case Code (Metric): 1608; Case Code (Imperial): 0603; Case/Package: 0603
R66 R67 = Res1: Resistor; Simulation: RESISTOR; Signal Integrity: Res; Library Name: Miscellaneous Devices.IntLib; Component Kind: Standard
T1 = Pulse Transformer: Manufacturer: Sumida; Inductance: 120uh; DC Resistance (DCR): 250mR; Width: 5.2mm; Termination: SMD/SMT; Supplier Part Number 1: 308-2353-1-ND; Supplier 1: Digi-Key; Stock 1: 10346; Pricing 1: 1=2.18, 10=1.742, 25=1.4156, 50=1.3794, 100=1.3431, 250=1.3068 (USD); Packaging: Tape and Reel; Package Quantity: 500; Min Operating Temperature: -40°C; Max Operating Temperature: 105°C; Manufacturer Part Number: ESMIT-4180/A; Library Name: Formula_Library.SchLib; Length: 7.1mm; Height - Seated (Max): 3.5mm; Height: 3.5mm; Description: Pulse Transformer; Component Kind: Standard; Case/Package: SMD/SMT
TP2-TP12 = Test Point: Material - Insulation: No Insulation; Type: PC Test Point; Supplier Part Number 1: A106145CT-ND; Supplier 1: Digi-Key; Stock 1: 136646; Size / Dimension: 0.063" x 0.031" x 0.045" (1.60mm x 0.80mm x 1.15mm); RoHS: RoHS Compliant; Pricing 1: 1=0.22, 10=0.206, 25=0.1756, 50=0.1536, 100=0.1426, 250=0.12616, 500=0.12068, 1000=0.09874 (USD); Plating: -; Part Status: Active; Packaging: Cut Tape (CT); Mounting Type: Surface Mount; Material - Body: -; Library Name: Formula_Library.SchLib; Description: Test Point; ComponentLink1URL: http://www.te.com/commerce/DocumentDelivery/DDEController?Action=srchrtrv&DocNm=1773266&DocType=DS&DocLang=English; ComponentLink1Description: http://www.te.com/commerce/DocumentDelivery/DDEController?Action=srchrtrv&DocNm=1773266&DocType=DS&DocLang=English; Component Kind: Standard; Color: Natural; Category: Test and Measurement
U1 U2 = SN65HVD256: TI CAN Transiever; Manufacturer Part Number: SN65HVD256DR; Manufacturer: Texas Instruments; Voltage - Supply: 4.5 V ~ 5.5 V; Receiver Hysteresis: 200mV; Number of Drivers/Receivers: 1/1; Duplex: Half; Data Rate: 1Mbps; Type: Transceiver; Supplier Part Number 1: 296-30093-1-ND; Supplier Device Package: 8-SOIC; Supplier 1: Digi-Key; Stock: 8542; RoHS: RoHS Compliant; Protocol: CAN; Pricing: 1=1.53, 10=1.368, 100=1.0665, 500=0.882, 1000=0.5625 (USD); Packaging: Cut Tape (CT); Package / Case: 8-SOIC (0.154", 3.90mm Width); Operating Temperature: -40°C ~ 125°C; Mounting Type: Surface Mount; ComponentLink1URL: http://www.ti.com/lit/ds/symlink/sn65hvd255.pdf; ComponentLink1Description: http://www.ti.com/lit/ds/symlink/sn65hvd255.pdf; Component Kind: Standard; Category: Integrated Circuits (ICs); Library Name: Wheel_Library.SchLib
U3 = LTC6820: isoSPI Isolated Communications Interface; Manufacturer Part Number: LTC6820IMS#PBF; Manufacturer: Linear Technology; Min Supply Voltage: 2.7V; Max Supply Voltage: 5.5V; Interface: SPI; Supplier Part Number 1: LTC6820IMS#PBF-ND; Supplier 1: Digi-Key; Stock 1: 2390; REACH SVHC: No SVHC; Pricing 1: 1=4.9, 25=3.2532, 100=2.67 (USD); Packaging: Rail/Tube; Package Quantity: 37; Number of Pins: 16; Min Operating Temperature: -40°C; Max Operating Temperature: 85°C; Library Name: Formula_Library.SchLib; ComponentLink2URL: https://datasheet.ciiva.com/22767/1730562-22767677.pdf; ComponentLink2Description: https://datasheet.ciiva.com/22767/1730562-22767677.pdf; ComponentLink1URL: https://datasheet.ciiva.com/22649/1730562-22649446.pdf; ComponentLink1Description: https://datasheet.ciiva.com/22649/1730562-22649446.pdf; Component Kind: Standard; Case/Package: MSOP
U5 = LT1790: IC VREF SERIES 5V SOT23-6; Manufacturer Part Number: LT1790AIS6-5#TRMPBF; Manufacturer: Linear Technology; Tolerance: 0.05%; Temperature Coefficient: 10ppm/°C; Reference Type: Series,Fixed; Quiescent Current: 60uA; Output Current: 5mA; Operating Supply Current: 80uA; Number of Channels: 1; Max Input Voltage: 20V; Width: 1.75mm; Supplier Part Number 1: LT1790AIS6-5#TRMPBFCT-ND; Supplier 1: Digi-Key; Pricing 1: 1=8.15, 25=7.2784, 100=4.2962, 250=3.9382 (USD); Packaging: Tape and Reel; Package Quantity: 500; Number of Pins: 6; Mount: Surface Mount; Min Output Voltage: 5V; Min Operating Temperature: -40°C; Max Output Voltage: 5V; Max Operating Temperature: 85°C; Library Name: Formula_Library.SchLib; Length: 2.9mm; Lead Free: Lead Free; Height: 0.9mm; ComponentLink5URL: https://datasheet.ciiva.com/26888/lt1790ais6-5-trmpbf-26888120.pdf; ComponentLink5Description: https://datasheet.ciiva.com/26888/lt1790ais6-5-trmpbf-26888120.pdf; ComponentLink4URL: https://datasheet.ciiva.com/29572/2433-29572139.pdf; ComponentLink4Description: https://datasheet.ciiva.com/29572/2433-29572139.pdf; ComponentLink3URL: https://datasheet.ciiva.com/29572/2433-29572138.pdf; ComponentLink3Description: https://datasheet.ciiva.com/29572/2433-29572138.pdf; ComponentLink2URL: https://datasheet.ciiva.com/29572/2433-29572137.pdf; ComponentLink2Description: https://datasheet.ciiva.com/29572/2433-29572137.pdf; ComponentLink1URL: https://datasheet.ciiva.com/25626/0900766b810ed953-25626193.pdf; ComponentLink1Description: https://datasheet.ciiva.com/25626/0900766b810ed953-25626193.pdf; Component Kind: Standard; Case/Package: SOT-23-6
U7 = LTC1864: LTC1864 - µPower, 16-Bit, 250ksps 1- and 2-Channel ADCs in SOIC; Manufacturer Part Number: LTC1864ACS8#PBF; Manufacturer: Linear Technology; Sampling Rate: 250KS/s; Resolution: 16b; Power Dissipation: 400mW; Polarity: Unipolar; Operating Supply Voltage: 5V; Number of Inputs: 1; Number of Bits: 16; Min Supply Voltage: 4.75V; Max Supply Voltage: 5.25V; Interface: SPI; Integral Nonlinearity (INL): 6LSB; Width: 3.99mm; Supplier Part Number 1: LTC1864ACS8#PBF-ND; Supplier 1: Digi-Key; Pricing 1: 1=14.74, 25=9.7848, 100=7.854 (USD); Packaging: Rail/Tube; Package Quantity: 100; Number of Pins: 8; Number of Elements: 1; Number of A/D Converters: 1; Mount: Surface Mount; Min Operating Temperature: 0°C; Max Operating Temperature: 70°C; Library Name: Formula_Library.SchLib; Length: 5mm; Lead Free: Lead Free; Height: 1.48mm; ComponentLink2URL: https://datasheet.ciiva.com/1258/4062-1258246.pdf; ComponentLink2Description: https://datasheet.ciiva.com/1258/4062-1258246.pdf; ComponentLink1URL: https://datasheet.ciiva.com/27053/1754541-27053360.pdf; ComponentLink1Description: https://datasheet.ciiva.com/27053/1754541-27053360.pdf; Component Kind: Standard; Case/Package: SOIC
